# Altium schematic sheet 219-03-2268.SchDoc
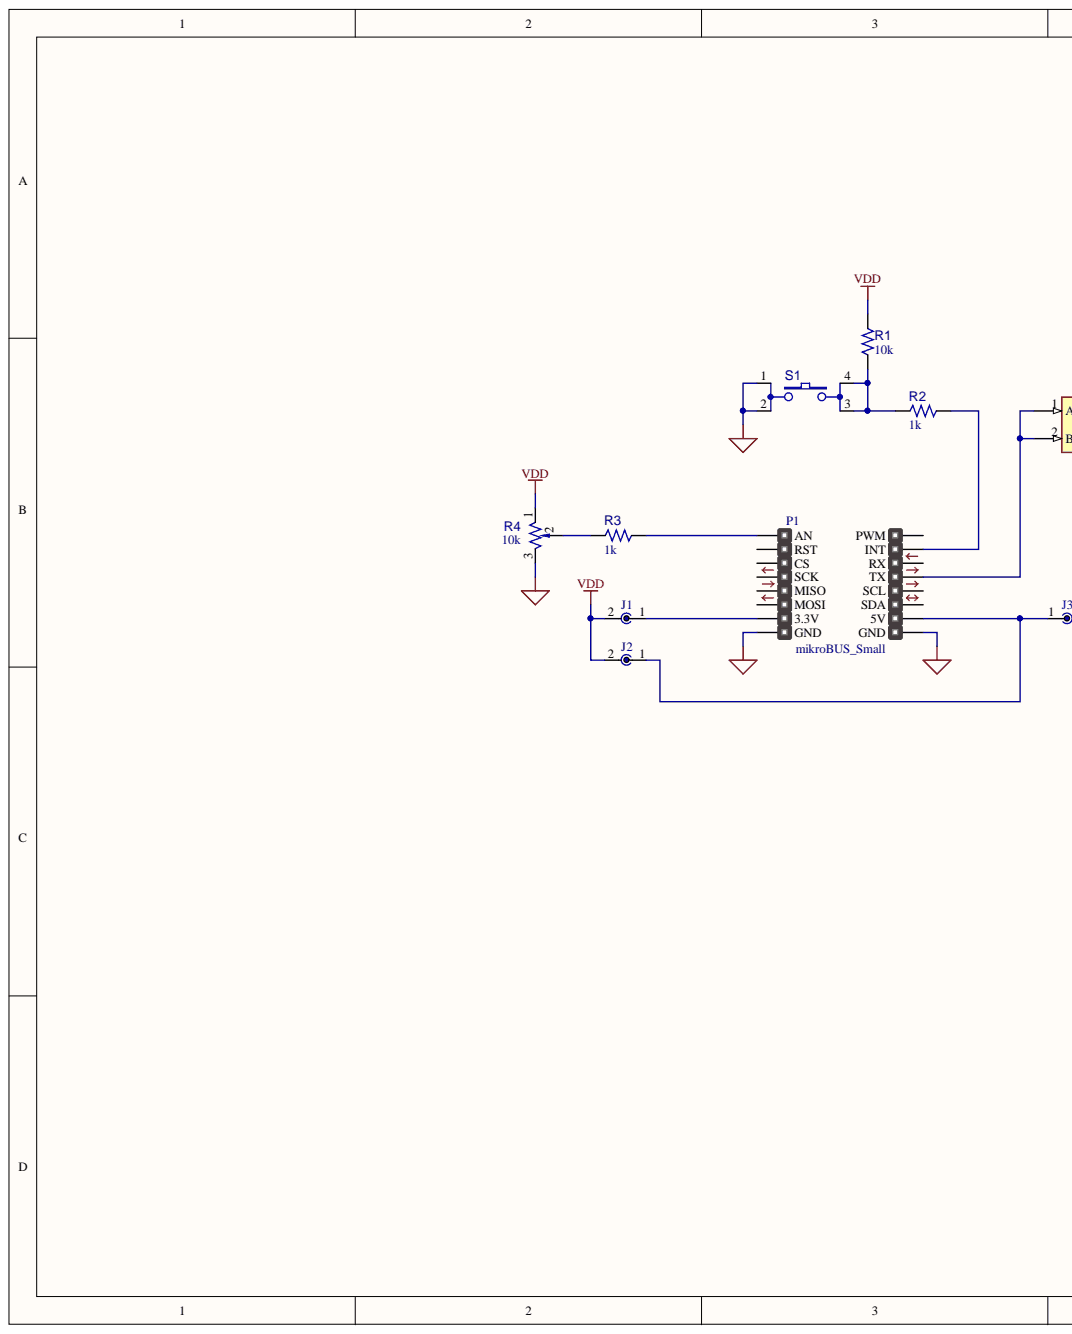
[diagram p0: 219-03-2268.SchDoc, left]
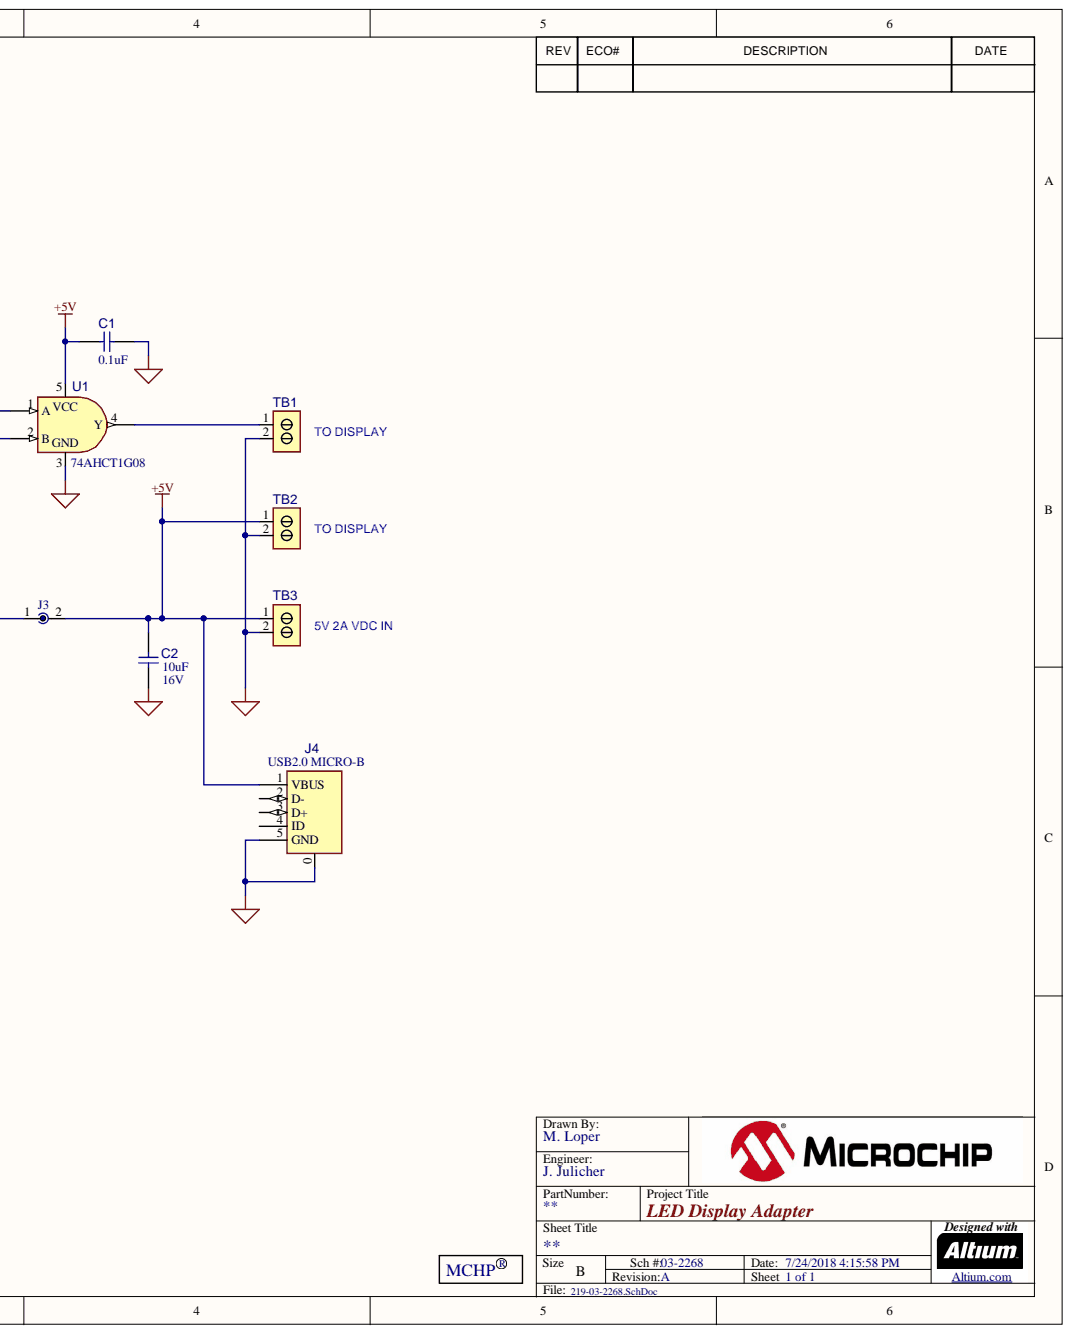
[diagram p1: 219-03-2268.SchDoc, right]

## Parts
C1  value 0.1uF  [CAP_C_0603]  (hidden comment: Capacitor NP)
C2  value 10uF  Voltage: 16V  [CAP_C_1206]  (hidden comment: Capacitor NP)
J1  JMPR_Solder  [JMPR_SOLDER]  (value not drawn)  (no BOM)
J2  JMPR_Solder  [JMPR_SOLDER]  (value not drawn)  (no BOM)
J3  JMPR_Solder  [JMPR_SOLDER]  (value not drawn)  (no BOM)
J4  value USB2.0 MICRO-B  [CON_USB_Micro-AB_SMD_TH_RA_FCI_10118194-0001LF]  (hidden comment: CON USB2.0 Mini_Micro)
LOGO1  Logo_MCHP_Small  [LOGO_MCHP_SMALL]  (value not drawn)  (no BOM)
P1  value mikroBUS_Small  [MOD_mikroBUS_MINI]  (hidden comment: Module mikroBUS_Add-on_standard)
R1  value 10k  [RES_C_0603]  (hidden comment: Resistor)
R2  value 1k  [RES_C_0603]  (hidden comment: Resistor)
R3  value 1k  [RES_C_0603]  (hidden comment: Resistor)
R4  value 10k  [RES_VAR_TTE_72PTRxxKLF_KNOB_L9.53W9.53H10.15]  (hidden comment: Resistor Var)
S1  Switch 4 Pin  [SW_TACT_SMD_B3S-1000]  (value not drawn)
TB1  Header 1x2  [CON_TERMINAL_5_1x2_TH_RA_PHO_1935161]  (value not drawn)
TB2  Header 1x2  [CON_TERMINAL_5_1x2_TH_RA_PHO_1935161]  (value not drawn)
TB3  Header 1x2  [CON_TERMINAL_5_1x2_TH_RA_PHO_1935161]  (value not drawn)
U1  value 74AHCT1G08  [SOT-23-5]  (hidden comment: 74XX1G08_9 SOT-23-5)

## Nets
+5V: C1.1 C2.1 J3.2 J4.1(VBUS) TB2.1 TB3.1 U1.5
GND: C1.2 C2.2 J4.0 J4.5(GND) P1.8(GND) P1.9(GND) R4.3 S1.1 S1.2 TB1.2 TB2.2 TB3.2 U1.3
VDD: J1.2 J2.2 R1.1 R4.1
NetJ1_1: J1.1 P1.7(3.3V)
NetJ2_1: J2.1 J3.1 P1.10(5V)
NetP1_1: P1.1(AN) R3.2
NetP1_13: P1.13(TX) U1.1(A_VCC) U1.2(B)
NetP1_15: P1.15(INT) R2.2
NetR1_2: R1.2 R2.1 S1.3 S1.4
NetR3_1: R3.1 R4.2
NetTB1_1: TB1.1 U1.4(Y)

Not connected: J4 2(D-) 3(D+) 4(ID); P1 2(RST) 3(CS) 4(SCK) 5(MISO) 6(MOSI) 11(SDA) 12(SCL) 14(RX) 16(PWM)

## Library data of the parts
C1 = CAP0455: Capacitor; Manufacturer 1: AVX; Manufacturer Part Number 1: 06035G104ZAT2A; Description_: CAP CER 0.1uF 50V 20% Y5V SMD 0603; Dev Tools Status: Production; Populated: Yes; Temperature Coeffcient: Y5V; Tolerance: 20%; Type: Ceramic; Voltage: 50V; Category: Capacitor; Component Kind: Standard; ComponentLink1Description: SVN Datasheet; ComponentLink1URL: https://chn-vm-apps.microchip.com/mchprepo/parts/Datasheets/ECJ__General_.pdf; ComponentLink2Description: Local Datasheet; ComponentLink3Description: Open Symbol; ComponentLink4Description: Open Footprint; ComponentLink5Description: Open Footprint 2; ComponentLink6Description: Open Footprint 3; ComponentLink7Description: Open Footprint 4; Database Table Name: Capacitor; Library Name: MCHP-CDB.DbLib; Manufacturer 2: Murata; Manufacturer 3: Panasonic; Manufacturer 4: TDK; Manufacturer 5: Yageo; Manufacturer Part Number 2: GRM188F51H104ZA01D; Manufacturer Part Number 3: ECJ-1VF1H104Z; Manufacturer Part Number 4: C1608Y5V1H104Z; Manufacturer Part Number 5: CC0603ZRY5V9BB104; MCHP-ID: CAP0455; Operating Temperature: -30C to +85C; Package: 0603; Quantity Override: 1; Revision: 1; Status: MCL Design; Supplier 1: Digi-Key; Supplier 2: Digi-Key; Supplier 3: Digi-Key; Supplier 4: Digi-Key; Supplier 5: Digi-Key; Supplier 6: Digi-Key; Supplier Part Number 1: 478-3724-1-ND; Supplier Part Number 2: 490-1568-2-ND; Supplier Part Number 3: PCC2153CT-ND; Supplier Part Number 4: 445-1324-1-ND; Supplier Part Number 5: 311-1343-2-ND; Supplier Part Number 6: 311-1343-6-ND; Symbol: Capacitor NP; ComponentLink2URL: C:\ALTIUM_WORK\00001_MCHP-CDB\Datasheets\ECJ__General_.pdf; ComponentLink3URL: C:\ALTIUM_WORK\00001_MCHP-CDB\Library\LibraryRefs\Capacitor NP.SchLib; ComponentLink4URL: C:\ALTIUM_WORK\00001_MCHP-CDB\Library\Footprints\CAP_C_0603.PcbLib
C2 = CAP0623: Capacitor; Manufacturer 1: KEMET; Manufacturer Part Number 1: C1206C106K4PACTU; Description_: CAP CER 10uF 16V 10% X5R SMD 1206; Dev Tools Status: Production; Populated: Yes; Temperature Coeffcient: X5R; Tolerance: 10%; Type: Ceramic; Category: Capacitor; Component Kind: Standard; ComponentLink1Description: SVN Datasheet; ComponentLink1URL: https://chn-vm-apps.microchip.com/mchprepo/parts/Datasheets/general_B11.pdf; ComponentLink2Description: Local Datasheet; ComponentLink3Description: Open Symbol; ComponentLink4Description: Open Footprint; ComponentLink5Description: Open Footprint 2; ComponentLink6Description: Open Footprint 3; ComponentLink7Description: Open Footprint 4; Database Table Name: Capacitor; Library Name: MCHP-CDB.DbLib; Manufacturer 2: TDK; Manufacturer 3: AVX Corporation; Manufacturer Part Number 2: C3216X5R1C106K; Manufacturer Part Number 3: 1206YD106KAT2A; MCHP-ID: CAP0623; Operating Temperature: -55C to +85C; Package: 1206; Quantity Override: 1; Revision: 1; Status: MCL Design; Supplier 1: Digi-Key; Supplier 2: Digi-Key; Supplier 3: Digi-Key; Supplier Part Number 1: 399-5091-1-ND; Supplier Part Number 2: 445-4052-1-ND; Supplier Part Number 3: 478-1573-1-ND; Symbol: Capacitor NP; ComponentLink2URL: C:\ALTIUM_WORK\00001_MCHP-CDB\Datasheets\general_B11.pdf; ComponentLink3URL: C:\ALTIUM_WORK\00001_MCHP-CDB\Library\LibraryRefs\Capacitor NP.SchLib; ComponentLink4URL: C:\ALTIUM_WORK\00001_MCHP-CDB\Library\Footprints\CAP_C_1206.PcbLib
J1-J3 = JMPR_Solder: Jumper, Solder Blob Shorting; Library Name: Mylib.SchLib
J4 = CON1383: Manufacturer 1: FCI; Manufacturer Part Number 1: 10118194-0001LF; Database Table Name: Connector; Description_: CON USB2.0 MICRO-B FEMALE TH/SMD R/A; Dev Tools Status: Production; Owner: Jamus Griego; Populated: YES; Category: Connector; Component Kind: Standard; ComponentLink1Description: SVN Datasheet; ComponentLink1URL: https://chn-vm-apps.microchip.com/mchprepo/parts/Datasheets/10118194.pdf; ComponentLink2Description: Local Datasheet; ComponentLink3Description: Open Symbol; ComponentLink4Description: Open Footprint; ComponentLink5Description: Open Footprint 2; ComponentLink6Description: Open Footprint 3; ComponentLink7Description: Open Footprint 4; Library Name: MCHP-CDB.DbLib; Manufacturer 2: YangMing; Manufacturer Part Number 2: YM-02-363-N05F; MCHP-ID: CON1383; Quantity Override: 1; Revision: 1; Status: MCL Design; Supplier 1: Digi-Key; Supplier 2: Raynen; Supplier Part Number 1: 609-4618-1-ND; Supplier Part Number 2: YangMing YM-02-363-N05F; Symbol: CON USB2.0 Mini_Micro; Type: USB; ComponentLink2URL: C:\ALTIUM_WORK\00001_MCHP-CDB\Datasheets\10118194.pdf; ComponentLink3URL: C:\ALTIUM_WORK\00001_MCHP-CDB\Library\LibraryRefs\CON USB2.0 Mini_Micro.SchLib; ComponentLink4URL: C:\ALTIUM_WORK\00001_MCHP-CDB\Library\Footprints\CON_USB_Micro-AB_SMD_TH_RA_FCI_10118194-0001LF.PcbLib
LOGO1 = Logo_MCHP_Small: Microchip Logo for PCB Silkscreen; Library Name: Mylib.SchLib
P1 = CON1406: mikroBUS Target Header; Database Table Name: Connector; Description_: Mikro BUS add-on Small Bd; Dev Tools Status: Design; Owner: Jose Garcia; Populated: YES; Category: Connector; Component Kind: Standard; ComponentLink1Description: SVN Datasheet; ComponentLink1URL: https://chn-vm-apps.microchip.com/mchprepo/parts/Datasheets/mikrobus_specification_standard.pdf; ComponentLink2Description: Local Datasheet; ComponentLink3Description: Open Symbol; ComponentLink4Description: Open Footprint; ComponentLink5Description: Open Footprint 2; ComponentLink6Description: Open Footprint 3; ComponentLink7Description: Open Footprint 4; Library Name: MCHP-CDB.DbLib; MCHP-ID: CON1406; Quantity Override: 1; Revision: 1; Status: MCL Design; Symbol: Module mikroBUS_Add-on_standard; Type: Modular; ComponentLink2URL: C:\ALTIUM_WORK\00001_MCHP-CDB\Datasheets\mikrobus_specification_standard.pdf; ComponentLink3URL: C:\ALTIUM_WORK\00001_MCHP-CDB\Library\LibraryRefs\Module mikroBUS_Add-on_standard.SchLib; ComponentLink4URL: C:\ALTIUM_WORK\00001_MCHP-CDB\Library\Footprints\MOD_mikroBUS_MINI.PcbLib
R1 = RSMT0139: Manufacturer 1: Panasonic; Manufacturer Part Number 1: ERJ-3EKF1002V; Description_: RES TKF 10k 1% 1/10W SMD 0603; Dev Tools Status: Production; Populated: Yes; Power: 1/10W; Temperature Coeffcient: 100ppm/C; Tolerance: 1%; Category: Resistor; Component Kind: Standard; ComponentLink1Description: SVN Datasheet; ComponentLink1URL: https://chn-vm-apps.microchip.com/mchprepo/parts/Datasheets/nrc.pdf; ComponentLink2Description: Local Datasheet; ComponentLink3Description: Open Symbol; ComponentLink4Description: Open Footprint; ComponentLink5Description: Open Footprint 2; ComponentLink6Description: Open Footprint 3; ComponentLink7Description: Open Footprint 4; Composition: TKF; Database Table Name: Resistor; Description: Resistor; Library Name: MCHP-CDB.DbLib; Manufacturer 2: Stackpole Electronics Inc; Manufacturer 3: Stackpole Electronics Inc; Manufacturer 4: Yageo; Manufacturer 5: Xicon; Manufacturer 6: NIC Components; Manufacturer Part Number 2: RMCF0603FT10K0; Manufacturer Part Number 3: RMCF 1/16 10K 1% R; Manufacturer Part Number 4: RC0603FR-0710KL; Manufacturer Part Number 5: 302-10K-RC; Manufacturer Part Number 6: NRC06F1002TRF; MCHP-ID: RSMT0139; Operating Temperature: -55C to +155C; Package: 0603; Quantity Override: 1; Revision: 2; Status: MCL Design; Supplier 1: Digi-Key; Supplier 2: Digi-Key; Supplier 3: Digi-Key; Supplier 4: Digi-Key; Supplier 5: Mouser; Supplier 6: NIC Components; Supplier Part Number 1: P10.0KHCT-ND; Supplier Part Number 2: RMCF1/1610KFRTR-ND; Supplier Part Number 3: RMCF0603FT10K0TR-ND; Supplier Part Number 4: 311-10.0KHRCT-ND; Supplier Part Number 5: 302-10k-RC; Supplier Part Number 6: NRC06F1002TRF; Symbol: Resistor; Type: RES SMD; ComponentLink2URL: C:\ALTIUM_WORK\00001_MCHP-CDB\Datasheets\nrc.pdf; ComponentLink3URL: C:\ALTIUM_WORK\00001_MCHP-CDB\Library\LibraryRefs\Resistor.SchLib; ComponentLink4URL: C:\ALTIUM_WORK\00001_MCHP-CDB\Library\Footprints\RES_C_0603.PcbLib
R2 R3 = RSMT0138: Manufacturer 1: Panasonic; Manufacturer Part Number 1: ERJ-3EKF1001V; Description_: RES TKF 1k 1% 1/10W SMD 0603; Dev Tools Status: Production; Populated: Yes; Power: 1/10W; Temperature Coeffcient: 100ppm/C; Tolerance: 1%; Voltage: 75V; Category: Resistor; Component Kind: Standard; ComponentLink1Description: SVN Datasheet; ComponentLink1URL: https://chn-vm-apps.microchip.com/mchprepo/parts/Datasheets/AOA0000CE2.pdf; ComponentLink2Description: Local Datasheet; ComponentLink3Description: Open Symbol; ComponentLink4Description: Open Footprint; ComponentLink5Description: Open Footprint 2; ComponentLink6Description: Open Footprint 3; ComponentLink7Description: Open Footprint 4; Composition: TKF; Database Table Name: Resistor; Description: Resistor; Library Name: MCHP-CDB.DbLib; Manufacturer 2: ROHM; Manufacturer 3: Stackpole Electronics Inc; Manufacturer 4: Stackpole Electronics Inc; Manufacturer 5: Vishay; Manufacturer 6: Stackpole Electronics Inc; Manufacturer 7: Yageo; Manufacturer 8: TE Connectivity; Manufacturer Part Number 2: MCR03EZPFX1001; Manufacturer Part Number 3: RMCF0603FT1K00; Manufacturer Part Number 4: RMCF 1/16 1K 1% R; Manufacturer Part Number 5: CRCW06031K00FKEA; Manufacturer Part Number 6: RMCF1/161.0K1%TR; Manufacturer Part Number 7: RC0603FR-071KL; Manufacturer Part Number 8: 1622866-1; MCHP-ID: RSMT0138; Operating Temperature: -55C to +155C; Package: 0603; Quantity Override: 1; Revision: 2; Status: MCL Design; Supplier 1: Digi-Key; Supplier 2: Digi-Key; Supplier 3: Digi-Key; Supplier 4: Digi-Key; Supplier 5: Digi-Key; Supplier 6: Newark; Supplier 7: Stackpole Electronics Inc; Supplier 8: Digi-Key; Supplier 9: Digi-Key; Supplier Part Number 1: P1.00KHCT-ND; Supplier Part Number 2: P1.00KHTR-ND; Supplier Part Number 3: RHM1.00KHCT-ND; Supplier Part Number 4: RMCF1/161KFRTR-ND; Supplier Part Number 5: RMCF0603FT1K00TR-ND; Supplier Part Number 6: 52K8015; Supplier Part Number 7: RMCF1/161.0K1%TR; Supplier Part Number 8: 311-1.00KHRCT-ND; Supplier Part Number 9: A106049CT-ND; Symbol: Resistor; Type: RES SMD; ComponentLink2URL: C:\ALTIUM_WORK\00001_MCHP-CDB\Datasheets\AOA0000CE2.pdf; ComponentLink3URL: C:\ALTIUM_WORK\00001_MCHP-CDB\Library\LibraryRefs\Resistor.SchLib; ComponentLink4URL: C:\ALTIUM_WORK\00001_MCHP-CDB\Library\Footprints\RES_C_0603.PcbLib
R4 = RES1583: Manufacturer 1: TT Electronics/BI                                                                               TT Electronics/BI; Manufacturer Part Number 1: 72PTR10KLF; Description_: RES VARIABLE C 10K 10% 1/2W TH 72PTR10KLF Knob; Dev Tools Status: Production; Owner: Mark Loper; Populated: YES; Power: 1/2W; Tolerance: 10%; Category: Resistor; Component Kind: Standard; ComponentLink1Description: SVN Datasheet; ComponentLink1URL: https://chn-vm-apps.microchip.com/mchprepo/parts/Datasheets/Datasheet; ComponentLink2Description: Local Datasheet; ComponentLink3Description: Open Symbol; ComponentLink4Description: Open Footprint; ComponentLink5Description: Open Footprint 2; ComponentLink6Description: Open Footprint 3; ComponentLink7Description: Open Footprint 4; Database Table Name: Resistor; Description: Resistor Var; Library Name: MCHP-CDB.DbLib; MCHP-ID: RES1583; Quantity Override: 1; Revision: 1; Status: MCL Design; Supplier 1: Digi-Key; Supplier Part Number 1: 987-1534-ND; Symbol: Resistor VAR; Type: Trimmer; ComponentLink2URL: C:\ALTIUM_WORK\00001_MCHP-CDB\Datasheets\Datasheet; ComponentLink3URL: C:\ALTIUM_WORK\00001_MCHP-CDB\Library\LibraryRefs\Resistor VAR.SchLib; ComponentLink4URL: C:\ALTIUM_WORK\00001_MCHP-CDB\Library\Footprints\RES_VAR_TTE_72PTRxxKLF_KNOB_L9.53W9.53H10.15.PcbLib
S1 = SWITCH1046: Switch; Manufacturer 1: Wurth Electronics Inc; Manufacturer Part Number 1: 430182043816; Description_: SWITCH TACT SPST 12V 50mA PTS645SM43SMTR92 LFS SMD; Dev Tools Status: Production; Owner: Jamus Griego; Populated: YES; Value: PTS645SM43SMTR92 LFS; Category: Switch; Component Kind: Standard; ComponentLink1Description: SVN Datasheet; ComponentLink1URL: https://chn-vm-apps.microchip.com/mchprepo/parts/Datasheets/PTS645_17dec14.pdf; ComponentLink2Description: Local Datasheet; ComponentLink3Description: Open Symbol; ComponentLink4Description: Open Footprint; ComponentLink5Description: Open Footprint 2; ComponentLink6Description: Open Footprint 3; ComponentLink7Description: Open Footprint 4; Database Table Name: Switch; Library Name: MCHP-CDB.DbLib; Manufacturer 2: C&K Components; Manufacturer 3: SWITECH; Manufacturer Part Number 2: PTS645SM43SMTR92 LFS; Manufacturer Part Number 3: TS2A-043C-G9; MCHP-ID: SWITCH1046; Quantity Override: 1; Revision: 1; Status: MCL Design; Supplier 1: Digi-Key; Supplier 2: Digi-Key; Supplier 3: Raynen; Supplier Part Number 1: 732-7004-1-ND; Supplier Part Number 2: CKN9112CT-ND; Supplier Part Number 3: SWITECH TS2A-043C-G9; Symbol: Switch 4 Pin; Type: Tactile; ComponentLink2URL: C:\ALTIUM_WORK\00001_MCHP-CDB\Datasheets\PTS645_17dec14.pdf; ComponentLink3URL: C:\ALTIUM_WORK\00001_MCHP-CDB\Library\LibraryRefs\Switch 4 Pin.SchLib; ComponentLink4URL: C:\ALTIUM_WORK\00001_MCHP-CDB\Library\Footprints\SW_TACT_SMD_B3S-1000.PcbLib
TB1-TB3 = CON0287: Manufacturer 1: Phoenix Contact; Manufacturer Part Number 1: 1935161; Current: 18A; Database Table Name: Connector; Description_: CON TERMINAL 5mm 1x2 Female 12-26AWG 18A TH R/A; Dev Tools Status: Production; Owner: Stephen Humberd; Populated: YES; Value: TERMINAL 1x2; Voltage: 300V; Category: Connector; Component Kind: Standard; ComponentLink1Description: SVN Datasheet; ComponentLink1URL: https://chn-vm-apps.microchip.com/mchprepo/parts/Datasheets/1935161.pdf; ComponentLink2Description: Local Datasheet; ComponentLink3Description: Open Symbol; ComponentLink4Description: Open Footprint; ComponentLink5Description: Open Footprint 2; ComponentLink6Description: Open Footprint 3; ComponentLink7Description: Open Footprint 4; Library Name: MCHP-CDB.DbLib; MCHP-ID: CON0287; Quantity Override: 1; Revision: 1; Status: MCL Design; Supplier 1: Digi-Key; Supplier Part Number 1: 277-1667-ND; Symbol: Header 1x2; Type: Terminal Block; ComponentLink2URL: C:\ALTIUM_WORK\00001_MCHP-CDB\Datasheets\1935161.pdf; ComponentLink3URL: C:\ALTIUM_WORK\00001_MCHP-CDB\Library\LibraryRefs\Header 1x2.SchLib; ComponentLink4URL: C:\ALTIUM_WORK\00001_MCHP-CDB\Library\Footprints\CON_TERMINAL_5_1x2_TH_RA_PHO_1935161.PcbLib
U1 = IC00097: Gate AND; Manufacturer 1: Texas Instruments; Manufacturer Part Number 1: SN74AHCT1G08DBVR; Database Table Name: IC; Description_: IC LOGIC 74AHCT1G08 SINGLE 2-INPUT AND GATE SOT-23-5; Dev Tools Status: Production; Populated: YES; Category: IC; Component Kind: Standard; ComponentLink1Description: SVN Datasheet; ComponentLink1URL: https://chn-vm-apps.microchip.com/mchprepo/parts/Datasheets/sn74ahct1g08.pdf; ComponentLink2Description: Local Datasheet; ComponentLink3Description: Open Symbol; ComponentLink4Description: Open Footprint; ComponentLink5Description: Open Footprint 2; ComponentLink6Description: Open Footprint 3; ComponentLink7Description: Open Footprint 4; Library Name: MCHP-CDB.DbLib; MCHP-ID: IC00097; Operating Temperature: -40C to +85C; Package: SOT-23-5; Quantity Override: 1; Revision: 1; Status: MCL Design; Supplier 1: Digi-Key; Supplier Part Number 1: 296-1113-1-ND; Symbol: 74XX1G08_9 SOT-23-5; Type: Logic; ComponentLink2URL: C:\ALTIUM_WORK\00001_MCHP-CDB\Datasheets\sn74ahct1g08.pdf; ComponentLink3URL: C:\ALTIUM_WORK\00001_MCHP-CDB\Library\LibraryRefs\74XX1G08_9 SOT-23-5.SchLib; ComponentLink4URL: C:\ALTIUM_WORK\00001_MCHP-CDB\Library\Footprints\SOT-23-5.PcbLib
